# Altium schematic sheet CPU.SchDoc(CPU)
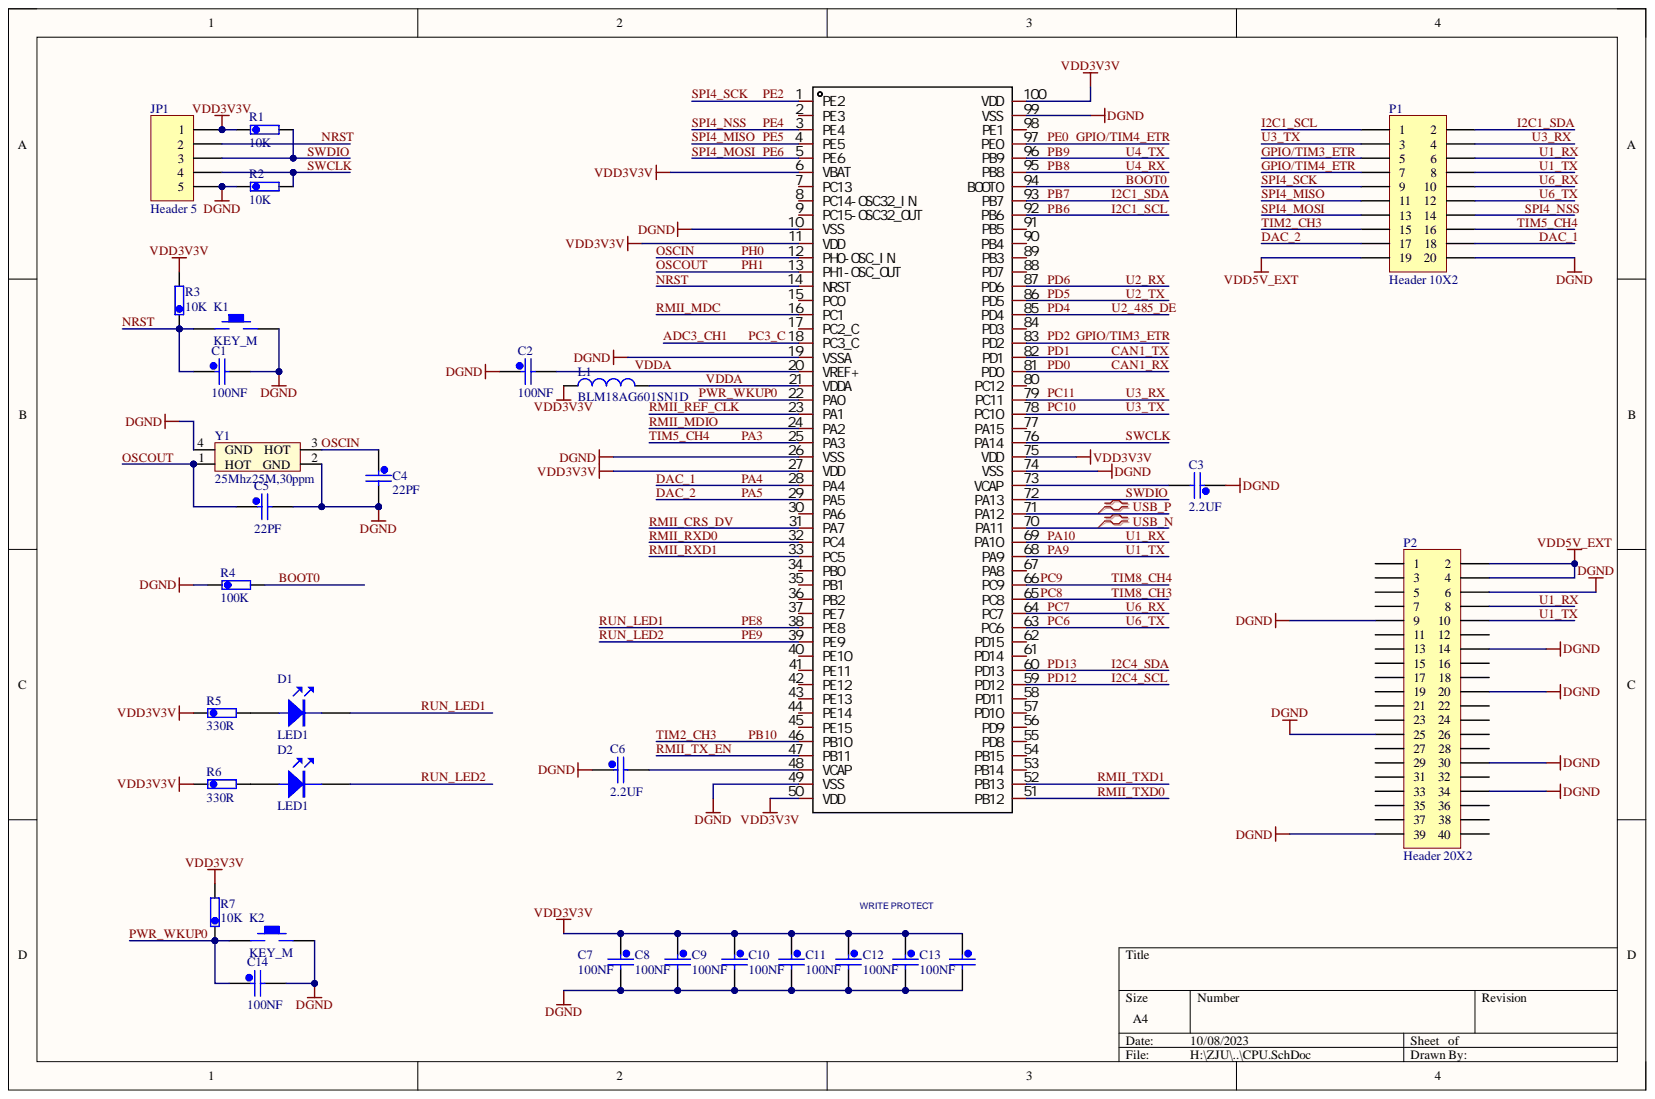
[diagram p0: CPU.SchDoc]

## Parts
C1  100NF  [0402C]
C2  100NF  [0402C]
C3  2.2UF  [0402C]
C4  22PF  [0402C]
C5  22PF  [0402C]
C6  2.2UF  [0402C]
C7  100NF  [0402C]
C8  100NF  [0402C]
C9  100NF  [0402C]
C10  100NF  [0402C]
C11  100NF  [0402C]
C12  100NF  [0402C]
C13  100NF  [0402C]
C14  100NF  [0402C]
D1  LED1  [LED-0603]
D2  LED1  [LED-0603]
JP1  Header 5  [PH5P]
K1  KEY_M  [KEY_SOP3625]
K2  KEY_M  [KEY_SOP3625]
L1  BLM18AG601SN1D  [0603R]
P1  Header 10X2  [CONN-TH_20P-P2.00_HC-PHB-2X10AW]
P2  Header 20X2  [40Pin]
R1  10K  [0402]
R2  10K  [0402]
R3  10K  [0402]
R4  100K  [0402]
R5  330R  [0402]
R6  330R  [0402]
R7  10K  [0402]
U1  STM32H743VGT6  [LQFP-100_L14.0-W14.0-P0.50-LS16.0-BL]  (value not drawn)
Y1  25Mhz25M,30ppm  [XTAL3225]

## Nets
DGND: C1.2 C2.1 C3.1 C4.2 C5.2 C6.1 C7.2 C8.2 C9.2 C10.2 C11.2 C12.2 C13.2 C14.2 JP1.5 K1.2 K2.2 P1.20 P2.6 P2.9 P2.14 P2.20 P2.25 P2.30 P2.34 P2.39 R2.1 R4.1 U1.10(VSS) U1.19(VSSA) U1.26(VSS) U1.49(VSS) U1.74(VSS) U1.99(VSS) Y1.2(GND) Y1.4(GND)
VDD3V3V: C7.1 C8.1 C9.1 C10.1 C11.1 C12.1 C13.1 JP1.1 L1.1 R1.1 R3.2 R5.1 R6.1 R7.2 U1.6(VBAT) U1.11(VDD) U1.27(VDD) U1.50(VDD) U1.75(VDD) U1.100(VDD)
VDD5V_EXT: P1.19 P2.2 P2.4
ADC3_CH1: U1.18(PC3_C)
BOOT0: R4.2 U1.94(BOOT0)
CAN1_RX: U1.81(PD0)
CAN1_TX: U1.82(PD1)
DAC_1: P1.18 U1.28(PA4)
DAC_2: P1.17 U1.29(PA5)
GPIO/TIM3_ETR: P1.5 U1.83(PD2)
GPIO/TIM4_ETR: P1.7 U1.97(PE0)
I2C1_SCL: P1.1 U1.92(PB6)
I2C1_SDA: P1.2 U1.93(PB7)
I2C4_SCL: U1.59(PD12)
I2C4_SDA: U1.60(PD13)
NRST: C1.1 JP1.2 K1.1 R3.1 U1.14(NRST)
OSCIN: C4.1 U1.12(PH0-OSC_IN) Y1.3(HOT)
OSCOUT: C5.1 U1.13(PH1-OSC_OUT) Y1.1(HOT)
PA3: P1.16 U1.25(PA3)
PA9: P1.8 P2.10 U1.68(PA9)
PA10: P1.6 P2.8 U1.69(PA10)
PB8: U1.95(PB8)
PB9: U1.96(PB9)
PB10: P1.15 U1.46(PB10)
PC6: P1.12 U1.63(PC6)
PC7: P1.10 U1.64(PC7)
PC8: U1.65(PC8)
PC9: U1.66(PC9)
PC10: P1.3 U1.78(PC10)
PC11: P1.4 U1.79(PC11)
PD4: U1.85(PD4)
PD5: U1.86(PD5)
PD6: U1.87(PD6)
PE2: P1.9 U1.1(PE2)
PE4: P1.14 U1.3(PE4)
PE5: P1.11 U1.4(PE5)
PE6: P1.13 U1.5(PE6)
PE8: D1.2 U1.38(PE8)
PE9: D2.2 U1.39(PE9)
PWR_WKUP0: C14.1 K2.1 R7.1 U1.22(PA0)
RMII_CRS_DV: U1.31(PA7)
RMII_MDC: U1.16(PC1)
RMII_MDIO: U1.24(PA2)
RMII_REF_CLK: U1.23(PA1)
RMII_RXD0: U1.32(PC4)
RMII_RXD1: U1.33(PC5)
RMII_TX_EN: U1.47(PB11)
RMII_TXD0: U1.51(PB12)
RMII_TXD1: U1.52(PB13)
SWCLK: JP1.4 R2.2 U1.76(PA14)
SWDIO: JP1.3 R1.2 U1.72(PA13)
USB_N: U1.70(PA11)
USB_P: U1.71(PA12)
VDDA: C2.2 L1.2 U1.20(VREF+) U1.21(VDDA)
NetC3_2: C3.2 U1.73(VCAP)
NetC6_2: C6.2 U1.48(VCAP)
NetD1_1: D1.1 R5.2
NetD2_1: D2.1 R6.2

Not connected: P2 1 3 5 7 11 12 13 15 16 17 18 19 21 22 23 24 26 27 28 29 31 32 33 35 36 37 38 40; U1 2(PE3) 7(PC13) 8(PC14-OSC32_IN) 9(PC15-OSC32_OUT) 15(PC0) 17(PC2_C) 30(PA6) 34(PB0) 35(PB1) 36(PB2) 37(PE7) 40(PE10) 41(PE11) 42(PE12) 43(PE13) 44(PE14) 45(PE15) 53(PB14) 54(PB15) 55(PD8) 56(PD9) 57(PD10) 58(PD11) 61(PD14) 62(PD15) 67(PA8) 77(PA15) 80(PC12) 84(PD3) 88(PD7) 89(PB3) 90(PB4) 91(PB5) 98(PE1)

## Library data of the parts
C1 C3-C6 C14 = CAP_4: Component Kind: Standard; Library Name: ROV.SCHLIB
C2 C7-C13 = CAP: Capacitors; Component Kind: Standard
D1 D2 = LED: Light-Emitting Diode; Component Kind: Standard
JP1 = Header 5: Header, 5-Pin; Component Kind: Standard; LatestRevisionDate: 17-Jul-2002; LatestRevisionNote: Re-released for DXP Platform.; Library Name: Miscellaneous Connectors.IntLib; Signal Integrity: Connector; Publisher: Altium Limited
L1 = Inductor: Value: 100uH; Component Kind: Standard; Description: BLM18AG601SN1D; Published: 8-Jun-2000; Revision: July-2002: Re-released for DXP Platform.; Library Name: ROV.SCHLIB; Publisher: Altium Limited
P1 = Header 10X2: Header, 10-Pin, Dual row; Component Kind: Standard; LatestRevisionDate: 17-Jul-2002; LatestRevisionNote: Re-released for DXP Platform.; Library Name: Miscellaneous Connectors.IntLib; Signal Integrity: Connector; Publisher: Altium Limited
P2 = Header 20X2: Header, 20-Pin, Dual row; Component Kind: Standard; LatestRevisionDate: 17-Jul-2002; LatestRevisionNote: Re-released for DXP Platform.; Library Name: Miscellaneous Connectors.IntLib; Signal Integrity: Connector; Publisher: Altium Limited
R1 R2 = RESA: Resistor; Component Kind: Standard
R3 R7 = RESA_3: Resistor; Component Kind: Standard; Library Name: ROV.SCHLIB
R4 = RESA_6: Resistance; Component Kind: Standard; Library Name: ROV.SCHLIB
R5 R6 = RESA: Resistance; Component Kind: Standard
U1 = STM32H743VGT6: Manufacturer: ST(意法半导体); Add into BOM: yes; Component Kind: Standard; Convert to PCB: yes; Datasheet: https://atta.szlcsc.com/upload/public/pdf/source/20200508/C529058_DA70143F266D6D825C3A912656F12A87.pdf; Description: STM32H743VGT6; Device: STM32H743VGT6; JLCPCB Part Class: Extended Part; LCSC Part Name: STM32H743VGT6; Manufacturer Part: STM32H743VGT6; Name: STM32H743VGT6; PCB3D: LQFP-100_L14.0-W14.0-H1.4-LS16.0-P0.50; Supplier: LCSC; Supplier Footprint: LQFP-100(14x14); Supplier Part: C730183; Symbol: STM32H743VGT6; Library Name: ROV_Control.SCHLIB
Y1 = XTAL_3225: 无源贴片晶振; Component Kind: Standard; Library Name: STM32H743_CORE.SCHLIB
K1 K2 = KEY_M: Component Kind: Standard; Library Name: STM32H743_CORE.SCHLIB
